# Altium schematic sheet Power.SchDoc(Power)
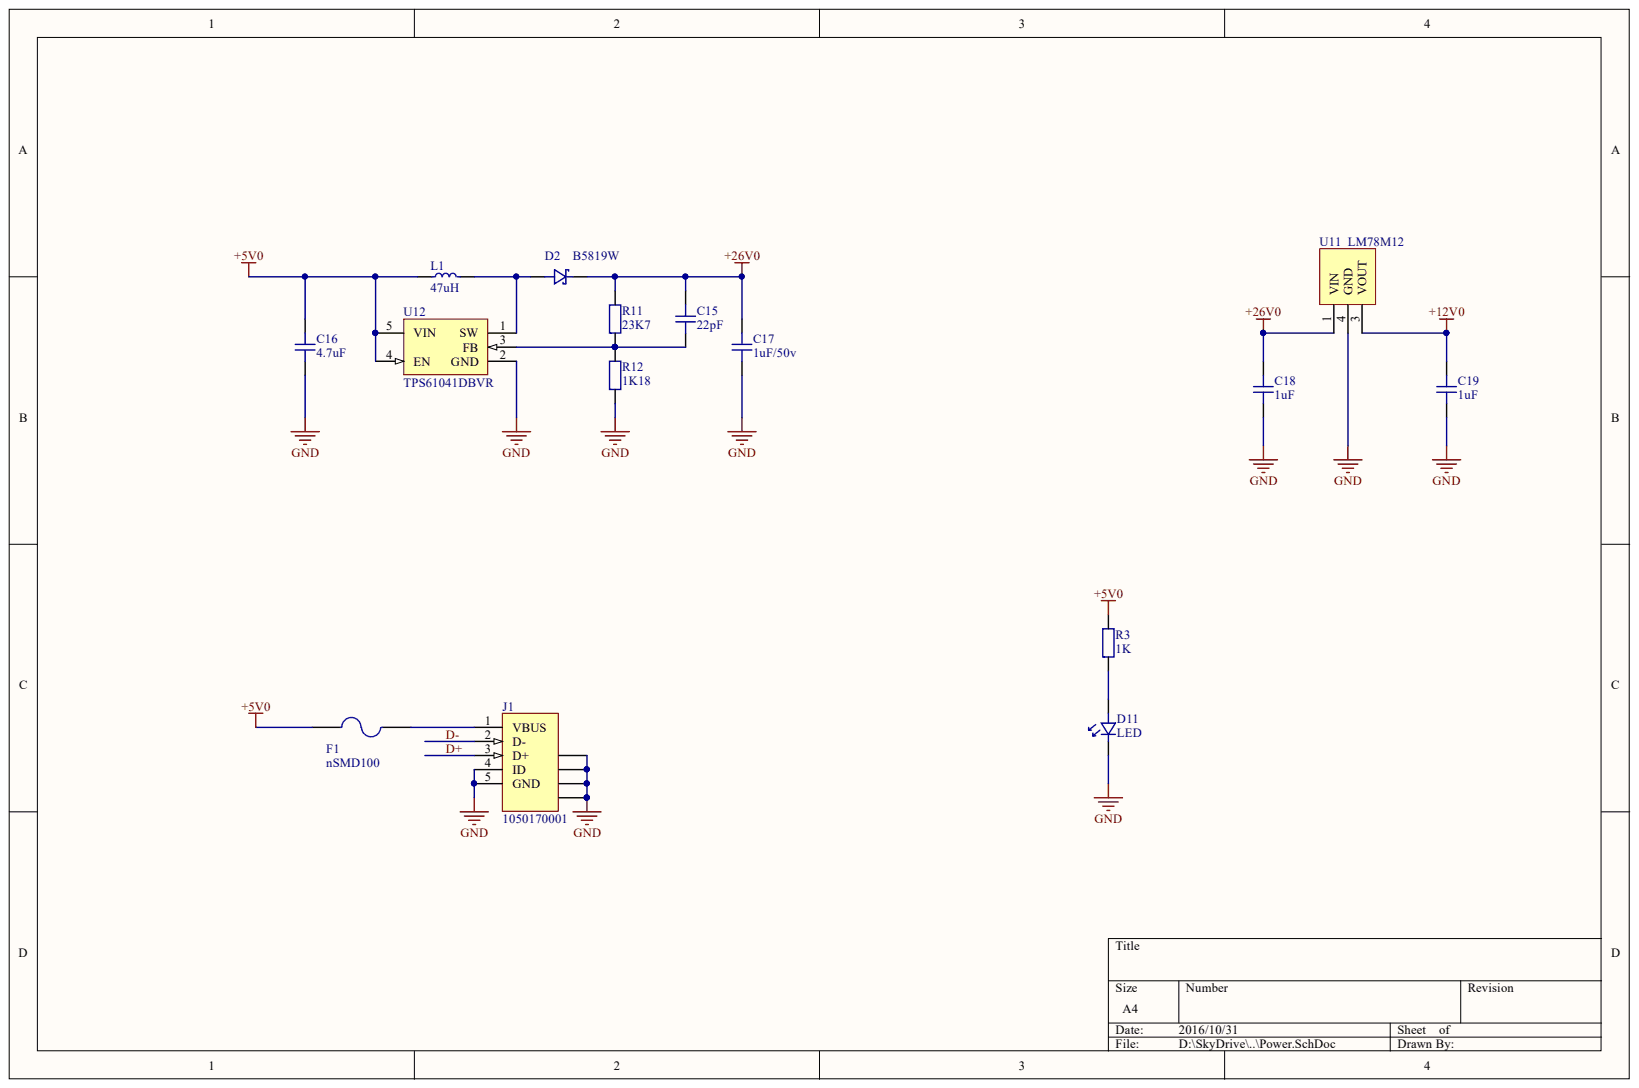
[diagram p0: Power.SchDoc]

## Parts
C15  22pF  [CAPC2012N]
C16  4.7uF  [CAPC2012N]
C17  1uF/50v  [CAPC2012N]
C18  1uF  [CAPC2012N]
C19  1uF  [CAPC2012N]
D2  B5819W  [SOD3716X135N]
D11  LED  [LEDC2012N]
F1  nSMD100  [FUSE3216N]
J1  1050170001  [USB_MICRO_2]
L1  47uH  [PWRIND_SWRH5D28S]
R3  1K  [RESC2012N]
R11  23K7  [RESC2012N]
R12  1K18  [RESC2012N]
U11  LM78M12  [TO252-3N]
U12  TPS61041DBVR  [SOT23-5_L]

## Nets
+5V0: C16.2 F1.1 L1.1 R3.1 U12.4(EN) U12.5(VIN)
+12V0: C19.2 U11.3
+26V0: C15.2 C17.2 C18.2 D2.1 R11.1 U11.1
GND: C16.1 C17.1 C18.1 C19.1 D11.1 J1.4(ID) J1.5(GND) J1.6 J1.7 J1.8 J1.9 R12.2 U11.4 U12.2(GND)
D+: J1.3(D+)
D-: J1.2(D-)
NetC15_1: C15.1 R11.2 R12.1 U12.3(FB)
NetD2_2: D2.2 L1.2 U12.1(SW)
NetD11_2: D11.2 R3.2
NetF1_2: F1.2 J1.1(VBUS)

## Library data of the parts
C15-C19 = CAP_CHP: Surface Mount Unpolarized Capacitor; Component Kind: Standard; Library Name: Discrete.SchLib
D2 = SKT_SMD: Surface Mount Diode; Component Kind: Standard; Library Name: Discrete.SchLib
D11 = LED_SMD: Surface Mount LED; Component Kind: Standard; Library Name: Discrete.SchLib
F1 = FUSE: 0.5A 6V Resetable Fuse; Component Kind: Standard; Library Name: Discrete.SchLib
J1 = USBMICRO: USB 2.0, Right Angle, SMT, A Type, Receptacle, 5 Position, Black; ContactMaterial: Phosphor Bronze; ContactPlating: Gold (30) over Nickel; DrillSize: Corresponds to nominal value.; PanelGround: Without; PCB_Layout: Complies with manufacturer's recommendation.; Component Kind: Standard; ComponentLink1Description: Manufacturer Link; ComponentLink1URL: http://www.tycoelectronics.com/; ComponentLink2Description: Packaging Specification; ComponentLink2URL: http://ecommas.tycoelectronics.com/commerce/DocumentDelivery/DDEController?Action=showdoc&DocId=Customer+Drawing%7F440478%7FB%7Fpdf%7FEnglish%7FC_440478_B.pdf; DatasheetDocument: 15-Nov-2002; HousingMaterial: Thermoplastic; Library Name: Connector.SchLib; PackageDocument: 15-Nov-2002; PackageReference: 440478; Published: 15-Jul-2004; Publisher: Altium Limited
L1 = IND_PWR: Power Inductor; Component Kind: Standard; Library Name: Discrete.SchLib
R3 R11 R12 = RES_CHP: Surface Mount Resistor; Component Kind: Standard; Library Name: Discrete.SchLib
U11 = LM7812: 3-Terminal Positive Voltage Regulators; Component Kind: Standard; Library Name: IC.SchLib
U12 = TPS61041DBVR: TPS6104x Low-Power DC-DC Boost Converter in SOT-23 and WSON Packages; Alternate Manufacturer: Texas Instruments; PartNumber: TPS61041DVBR; Alternate PartNumber: TPS61041; Component Kind: Standard; Library Name: IC.SchLib
